# Altium schematic sheet 04-uHeader_JX2.SchDoc(04-uHeader_JX2)
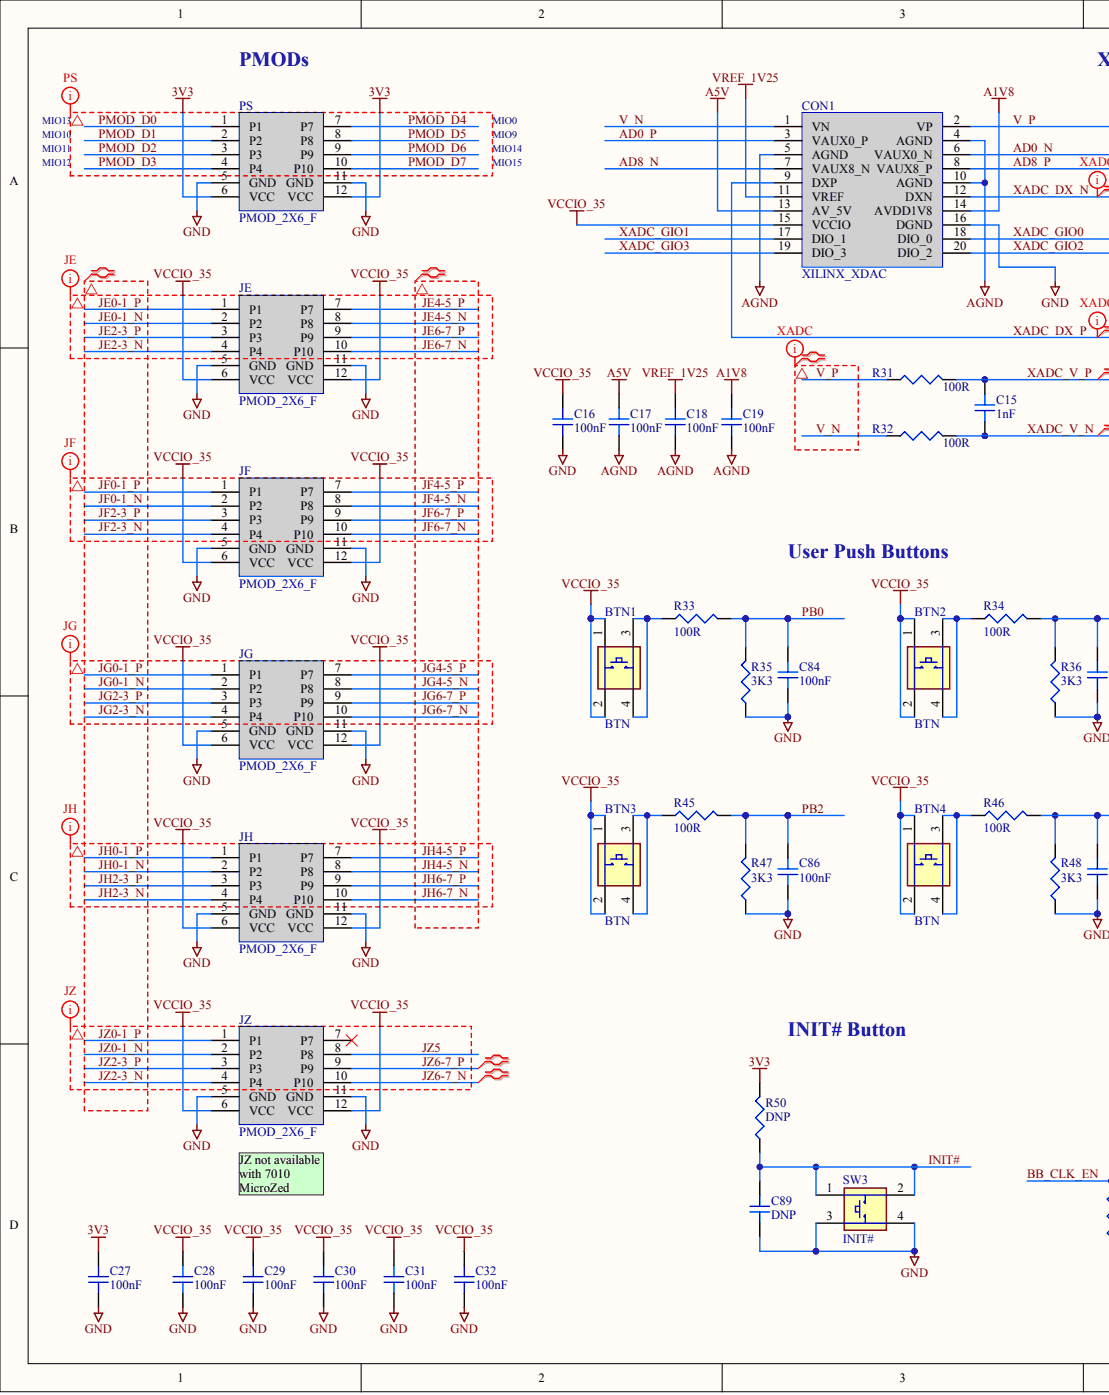
[diagram p0: 04-uHeader_JX2.SchDoc, left]
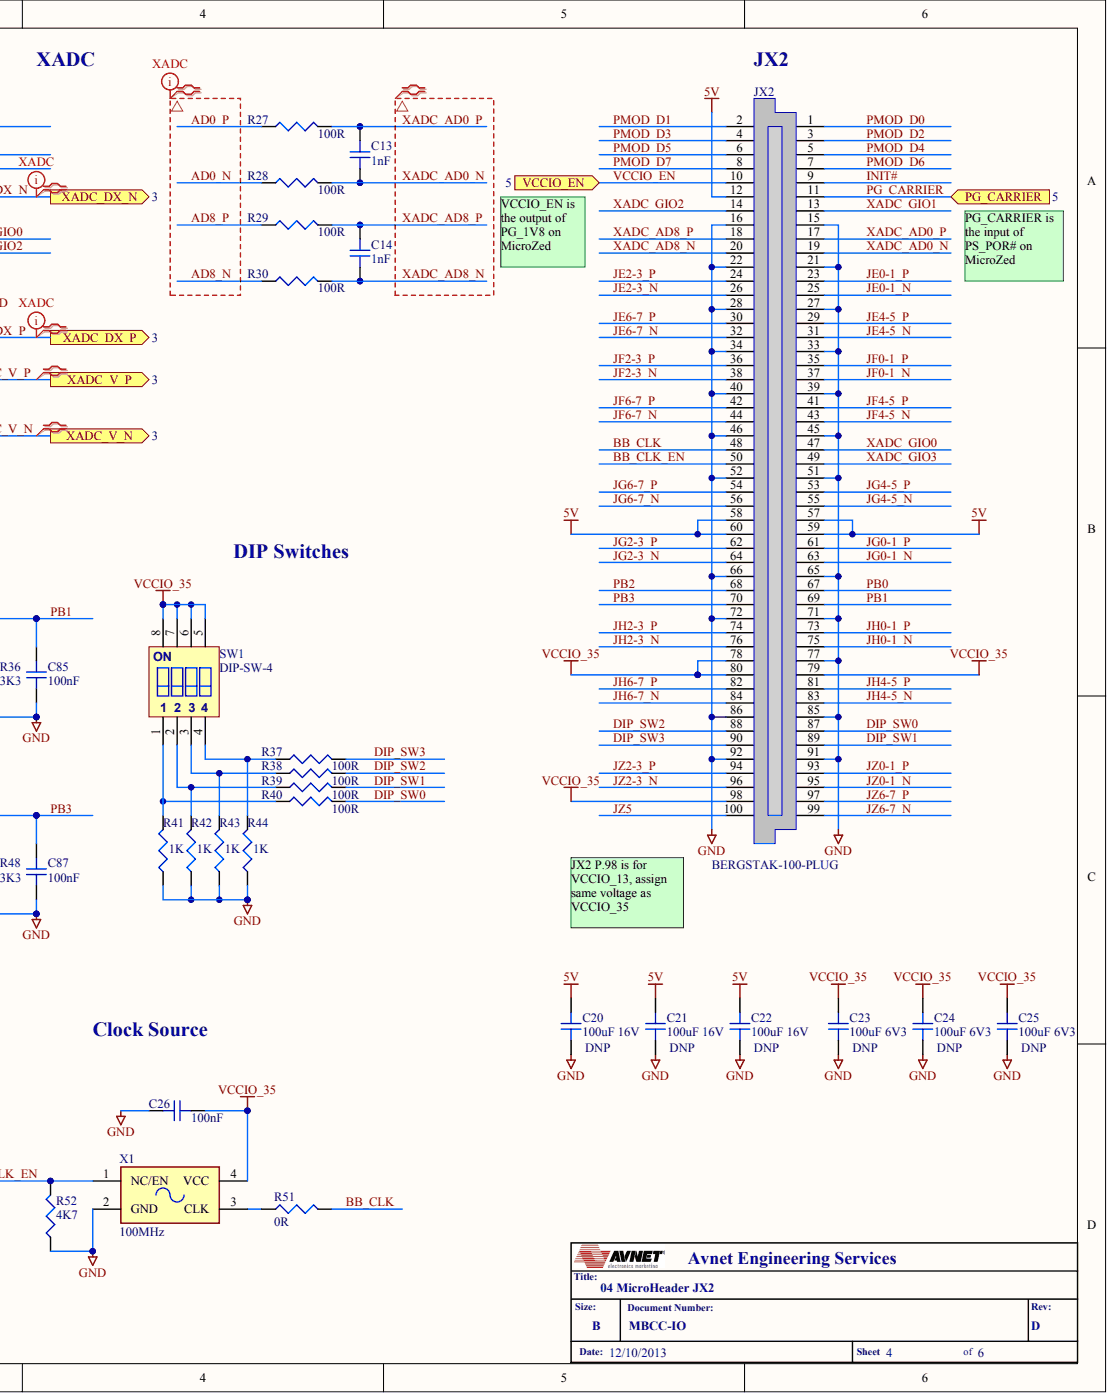
[diagram p1: 04-uHeader_JX2.SchDoc, right]

## Parts
BTN1  BTN
BTN2  BTN
BTN3  BTN
BTN4  BTN
C13  1nF
C14  1nF
C15  1nF
C16  100nF
C17  100nF
C18  100nF
C19  100nF
C20  100uF 16V
C21  100uF 16V
C22  100uF 16V
C23  100uF 6V3
C24  100uF 6V3
C25  100uF 6V3
C26  100nF
C27  100nF
C28  100nF
C29  100nF
C30  100nF
C31  100nF
C32  100nF
C84  100nF
C85  100nF
C86  100nF
C87  100nF
C89  DNP
CON1  XILINX_XDAC
JE  PMOD_2X6_F
JF  PMOD_2X6_F
JG  PMOD_2X6_F
JH  PMOD_2X6_F
JX2  BERGSTAK-100-PLUG
JZ  PMOD_2X6_F
PS  PMOD_2X6_F
R27  100R
R28  100R
R29  100R
R30  100R
R31  100R
R32  100R
R33  100R
R34  100R
R35  3K3
R36  3K3
R37  100R
R38  100R
R39  100R
R40  100R
R41  1K
R42  1K
R43  1K
R44  1K
R45  100R
R46  100R
R47  3K3
R48  3K3
R50  DNP
R51  0R
R52  4K7
SW1  DIP-SW-4
SW3  INIT#
X1  100MHz

## Ports
PG_CARRIER, VCCIO_EN, XADC_DX_N, XADC_DX_P, XADC_V_N, XADC_V_P

## Nets
3V3: C27.1 PS.6(VCC) PS.12(VCC) R50.2
5V: C20.1 C21.1 C22.1 JX2.12 JX2.57 JX2.58 JX2.59 JX2.60
A1V8: C19.1 CON1.14(AVDD1V8)
A5V: C17.1 CON1.13(AV_5V)
AGND: C17.2 C18.2 C19.2 CON1.4(AGND) CON1.5(AGND) CON1.10(AGND)
GND: C16.2 C20.2 C21.2 C22.2 C23.2 C24.2 C25.2 C26.1 C27.2 C28.2 C29.2 C30.2 C31.2 C32.2 C84.2 C85.2 C86.2 C87.2 C89.2 CON1.16(DGND) JE.5(GND) JE.11(GND) JF.5(GND) JF.11(GND) JG.5(GND) JG.11(GND) JH.5(GND) JH.11(GND) JX2.15 JX2.16 JX2.21 JX2.22 JX2.27 JX2.28 JX2.33 JX2.34 JX2.39 JX2.40 JX2.45 JX2.46 JX2.51 JX2.52 JX2.65 JX2.66 JX2.71 JX2.72 JX2.77 JX2.85 JX2.86 JX2.91 JX2.92 JZ.5(GND) JZ.11(GND) PS.5(GND) PS.11(GND) R35.1 R36.1 R41.1 R42.1 R43.1 R44.1 R47.1 R48.1 R52.1 SW3.3 SW3.4 X1.2(GND)
VCCIO_35: BTN1.1 BTN1.2 BTN2.1 BTN2.2 BTN3.1 BTN3.2 BTN4.1 BTN4.2 C16.1 C23.1 C24.1 C25.1 C26.2 C28.1 C29.1 C30.1 C31.1 C32.1 CON1.15(VCCIO) JE.6(VCC) JE.12(VCC) JF.6(VCC) JF.12(VCC) JG.6(VCC) JG.12(VCC) JH.6(VCC) JH.12(VCC) JX2.78 JX2.79 JX2.80 JX2.98 JZ.6(VCC) JZ.12(VCC) SW1.5 SW1.6 SW1.7 SW1.8(ON) X1.4(VCC)
VREF_1V25: C18.1 CON1.11(VREF)
AD0_N: CON1.6(VAUX0_N) R28.1
AD0_P: CON1.3(VAUX0_P) R27.1
AD8_N: CON1.7(VAUX8_N) R30.1
AD8_P: CON1.8(VAUX8_P) R29.1
BB_CLK: JX2.48 R51.2
BB_CLK_EN: JX2.50 R52.2 X1.1(NC/EN)
DIP_SW0: JX2.87 R40.2
DIP_SW1: JX2.89 R39.2
DIP_SW2: JX2.88 R38.2
DIP_SW3: JX2.90 R37.2
INIT#: C89.1 JX2.9 R50.1 SW3.1 SW3.2
JE0-1_N: JE.2(P2) JX2.25
JE0-1_P: JE.1(P1) JX2.23
JE2-3_N: JE.4(P4) JX2.26
JE2-3_P: JE.3(P3) JX2.24
JE4-5_N: JE.8(P8) JX2.31
JE4-5_P: JE.7(P7) JX2.29
JE6-7_N: JE.10(P10) JX2.32
JE6-7_P: JE.9(P9) JX2.30
JF0-1_N: JF.2(P2) JX2.37
JF0-1_P: JF.1(P1) JX2.35
JF2-3_N: JF.4(P4) JX2.38
JF2-3_P: JF.3(P3) JX2.36
JF4-5_N: JF.8(P8) JX2.43
JF4-5_P: JF.7(P7) JX2.41
JF6-7_N: JF.10(P10) JX2.44
JF6-7_P: JF.9(P9) JX2.42
JG0-1_N: JG.2(P2) JX2.63
JG0-1_P: JG.1(P1) JX2.61
JG2-3_N: JG.4(P4) JX2.64
JG2-3_P: JG.3(P3) JX2.62
JG4-5_N: JG.8(P8) JX2.55
JG4-5_P: JG.7(P7) JX2.53
JG6-7_N: JG.10(P10) JX2.56
JG6-7_P: JG.9(P9) JX2.54
JH0-1_N: JH.2(P2) JX2.75
JH0-1_P: JH.1(P1) JX2.73
JH2-3_N: JH.4(P4) JX2.76
JH2-3_P: JH.3(P3) JX2.74
JH4-5_N: JH.8(P8) JX2.83
JH4-5_P: JH.7(P7) JX2.81
JH6-7_N: JH.10(P10) JX2.84
JH6-7_P: JH.9(P9) JX2.82
JZ0-1_N: JX2.95 JZ.2(P2)
JZ0-1_P: JX2.93 JZ.1(P1)
JZ2-3_N: JX2.96 JZ.4(P4)
JZ2-3_P: JX2.94 JZ.3(P3)
JZ5: JX2.100 JZ.8(P8)
JZ6-7_N: JX2.99 JZ.10(P10)
JZ6-7_P: JX2.97 JZ.9(P9)
PB0: C84.1 JX2.67 R33.2 R35.2
PB1: C85.1 JX2.69 R34.2 R36.2
PB2: C86.1 JX2.68 R45.2 R47.2
PB3: C87.1 JX2.70 R46.2 R48.2
PG_CARRIER: JX2.11 ; port PG_CARRIER
PMOD_D0: JX2.1 PS.1(P1)
PMOD_D1: JX2.2 PS.2(P2)
PMOD_D2: JX2.3 PS.3(P3)
PMOD_D3: JX2.4 PS.4(P4)
PMOD_D4: JX2.5 PS.7(P7)
PMOD_D5: JX2.6 PS.8(P8)
PMOD_D6: JX2.7 PS.9(P9)
PMOD_D7: JX2.8 PS.10(P10)
V_N: CON1.1(VN) R32.1
V_P: CON1.2(VP) R31.1
VCCIO_EN: JX2.10 ; port VCCIO_EN
XADC_AD0_N: C13.1 JX2.19 R28.2
XADC_AD0_P: C13.2 JX2.17 R27.2
XADC_AD8_N: C14.1 JX2.20 R30.2
XADC_AD8_P: C14.2 JX2.18 R29.2
XADC_DX_N: CON1.12(DXN) ; port XADC_DX_N
XADC_DX_P: CON1.9(DXP) ; port XADC_DX_P
XADC_GIO0: CON1.18(DIO_0) JX2.47
XADC_GIO1: CON1.17(DIO_1) JX2.13
XADC_GIO2: CON1.20(DIO_2) JX2.14
XADC_GIO3: CON1.19(DIO_3) JX2.49
XADC_V_N: C15.1 R32.2 ; port XADC_V_N
XADC_V_P: C15.2 R31.2 ; port XADC_V_P
NetBTN1_3: BTN1.3 BTN1.4 R33.1
NetBTN2_3: BTN2.3 BTN2.4 R34.1
NetBTN3_3: BTN3.3 BTN3.4 R45.1
NetBTN4_3: BTN4.3 BTN4.4 R46.1
NetR37_1: R37.1 R44.2 SW1.4
NetR38_1: R38.1 R43.2 SW1.3
NetR39_1: R39.1 R42.2 SW1.2
NetR40_1: R40.1 R41.2 SW1.1
NetR51_1: R51.1 X1.3(CLK)
N00184:
N00185:
N00186:
N00187:
N00188:
N00189:
N00190:
N00191:
N00192:
N00193:
N00194:

Not connected: JZ 7(P7)

## Library data of the parts
BTN1-BTN4 = (no symbol name): MFG#1/Part#: TE: 1825910-6 (FSM4JH); MFG#B/Part#: TE: 4-1437565-1 (FSM4JSMA)
C13-C15 = (no symbol name): MFG#1/Part#: AVX:06035C102KAT2A
C16-C19 C26-C32 C84-C87 = (no symbol name): MFG#1/Part#: AVX:06035C104KAT2A
C20-C22 = (no symbol name): MFG#1/Part#: TYC: EMK325ABJ107MM-T; DNP: DNP
C23-C25 = (no symbol name): MFG#1/Part#: muRata: GRM32EF50J107ZE20K; DNP: DNP
C89 = (no symbol name): MFG#1/Part#: AVX:06035CxxxKAT2A; DNP: DNP
CON1 = (no symbol name): MFG#1/Part#: Samtec: TST-110-01-G-D
JE JF JG JH JZ PS = (no symbol name): MFG#B/Part#: TE: 5-147105-6; MFG#1/Part#: SAMTEC: SSW-106-02-F-D-RA
JX2 = (no symbol name): MFG#1/Part#: FCI: 61083-101400LF
R27-R34 R37-R40 R45 R46 = (no symbol name): MFG#1/Part#: Vishay: CRCW0603100RJNEA
R35 R36 R47 R48 = (no symbol name): MFG#1/Part#: Vishay: CRCW06033K30JNEA
R41-R44 = (no symbol name): MFG#1/Part#: Vishay: CRCW06031K00JNEA
R50 = (no symbol name): MFG#1/Part#: Vishay: CRCW0603xxxxJNEA; DNP: DNP
R51 = (no symbol name): MFG#1/Part#: Vishay: CRCW06030000Z0EA
R52 = (no symbol name): MFG#1/Part#: Vishay: CRCW06034K70JNEA
SW1 = (no symbol name): MFG#A/Part#: C&K: TDA04H0SB1; MFG#1/Part#: TE: 1825058-7 (ADE04S04)
SW3 = (no symbol name): MFG#1/Part#: TE: 1825910-7 (FSM4JAH); MFG#B/Part#: TE: 4-1437565-1 (FSM4JSMA)
X1 = (no symbol name): MFG#C/Part#: MMD: MIMH3050-XXXXMHZ-T; MFG#B/Part#: FOX:; MFG#A/Part#: MMD: MSH3050-XXXXMHZ-T; MFG#1/Part#: Discera: DSC1001DE1-100.0000T
